# Altium schematic sheet CL212I31-POW.SchDoc
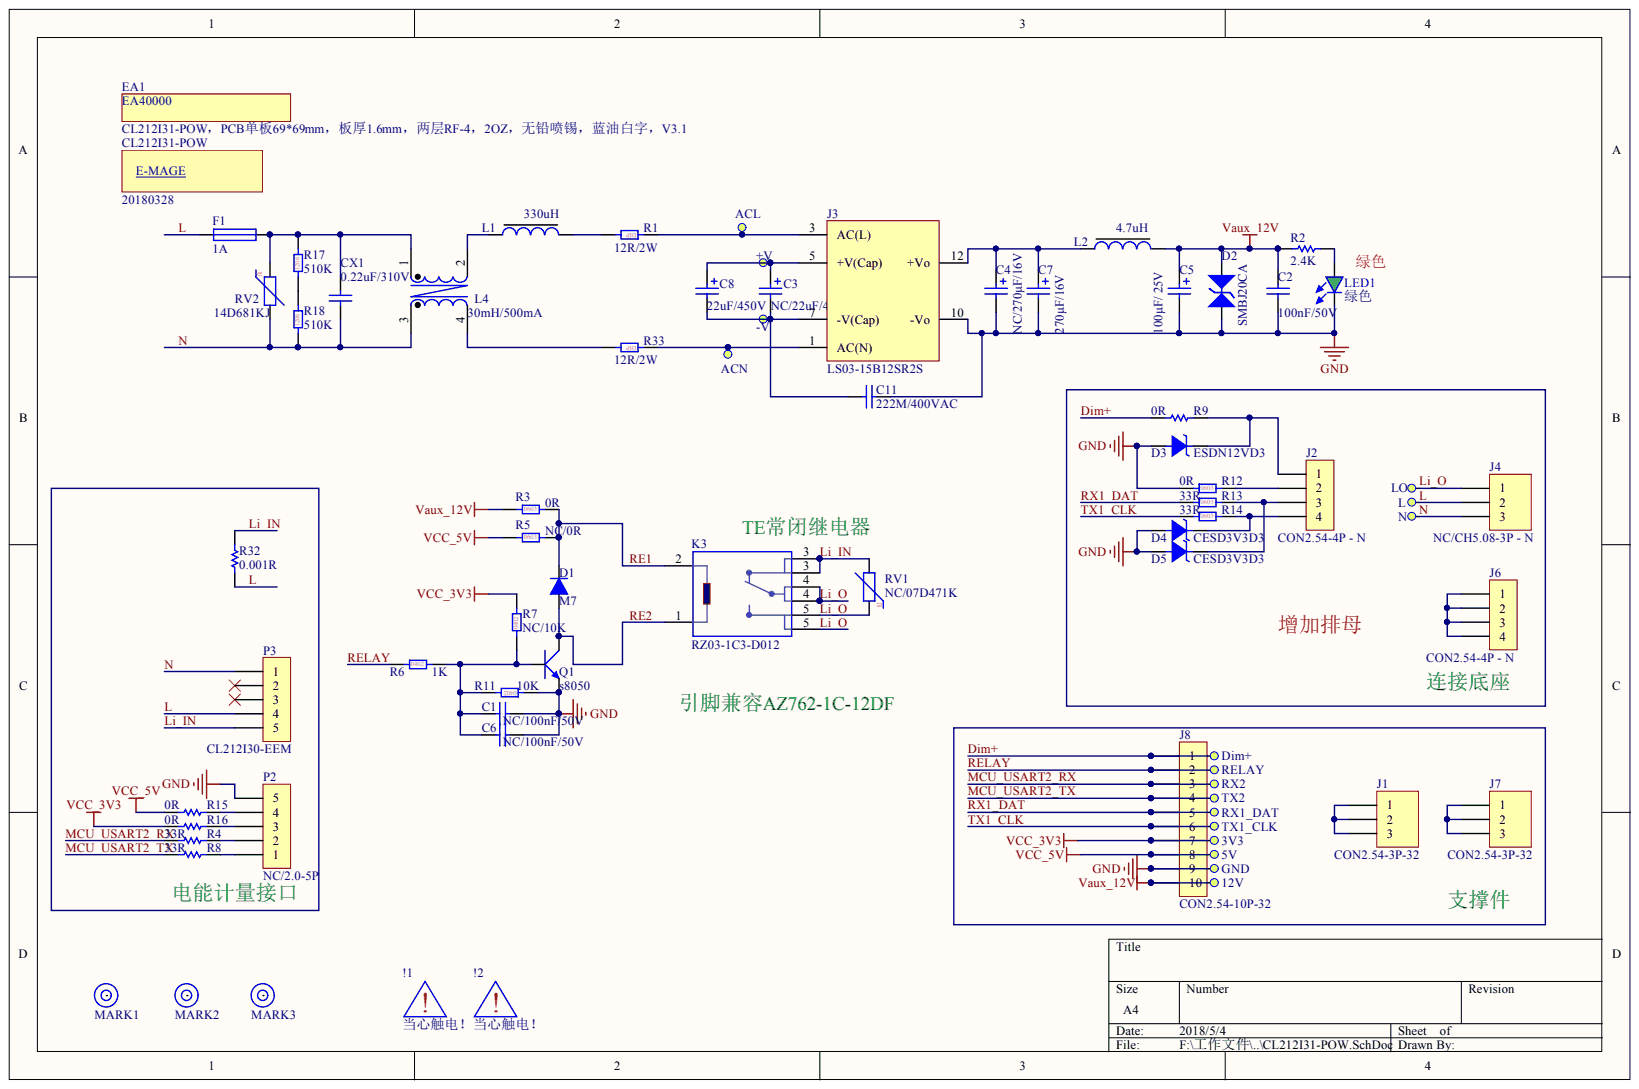
[diagram p0: CL212I31-POW.SchDoc]

## Parts
3V3  Test-Point  [Test-Point]  (value not drawn)  (no BOM)
5V  Test-Point  [Test-Point]  (value not drawn)  (no BOM)
12V  Test-Point  [Test-Point]  (value not drawn)  (no BOM)
!1  NC  [BMP1]  (value not drawn)
!2  NC  [BMP1]  (value not drawn)
+V  Test-Point  [Test-Point]  (value not drawn)  (no BOM)
-V  Test-Point  [Test-Point]  (value not drawn)  (no BOM)
ACL  Test-Point  [Test-Point]  (value not drawn)  (no BOM)
ACN  Test-Point  [Test-Point]  (value not drawn)  (no BOM)
C1  NC/100nF/50V  [C0402]
C2  100nF/50V  [C0402]
C3  NC/22uF/450V  [CE16*25_7.5]
C4  NC/270µF/16V  [CE8*9_3.5]
C5  100µF/ 25V  [CE6.3*7.7-SM]
C6  NC/100nF/50V  [C0402]
C7  270µF/16V  [CE6.3*9_2.5]
C8  22uF/450V  [CE12.5*20_5]
C11  222M/400VAC  [C10MM]
CL212I31-POW  20180328  [E-MAGE]
CX1  0.22uF/310V  [RAD-10MM]
D1  M7  [sma]
D2  SMBJ20CA  [smb]
D3  ESDN12VD3  [SOD323]
D4  CESD3V3D3  [SOD323]
D5  CESD3V3D3  [SOD323]
Dim+  Test-Point  [Test-Point]  (value not drawn)  (no BOM)
EA1  CL212I31-POW，PCB单板69*69mm，板厚1.6mm，两层RF-4，2OZ，无铅喷锡，蓝油白字，V3.1  [PCB_Coding]
F1  1A  [F_R8.4_4]
GND  Test-Point  [Test-Point]  (value not drawn)  (no BOM)
J1  CON2.54-3P-32  [CON2.54-3P-32]
J2  CON2.54-4P - N  [CON2.54-4P - N]
J3  LS03-15B12SR2S  [LS03-15B12SR2S]
J4  NC/CH5.08-3P - N  [CH5.08-3P - N]
J6  CON2.54-4P - N  [CON2.54-4P - N]
J7  CON2.54-3P-32  [CON2.54-3P-32]
J8  CON2.54-10P-32  [CON2.54-10P-32]
K3  RZ03-1C3-D012  [RELAY_TE_RZ]
L  Test-Point  [Test-Point]  (value not drawn)  (no BOM)
L1  330uH  [L_8*10-5]  (net tie)
L2  4.7uH  [L-HNR4030]  (net tie)
L4  30mH/500mA  [UU98]
LED1  绿色  [LED0603 G]
LO  Test-Point  [Test-Point]  (value not drawn)  (no BOM)
MARK1  MARK  [MARK]
MARK2  MARK  [MARK]
MARK3  MARK  [MARK]
N  Test-Point  [Test-Point]  (value not drawn)  (no BOM)
P2  NC/2.0-5P  [CON2.0-5P]
P3  CL212I30-EEM  [CON2.0-5P-8209C]
Q1  s8050  [sot23-3]
R1  12R/2W  [R_AXIAL_2W_5.08_90]
R2  2.4K  [R0805]
R3  0R  [R0603]
R4  33R  [R0402]
R5  NC/0R  [R0603]
R6  1K  [R0402]
R7  NC/10K  [R0402]
R8  33R  [R0402]
R9  0R  [R0402]
R11  10K  [R0402]
R12  0R  [R0603]
R13  33R  [R0603]
R14  33R  [R0603]
R15  0R  [R0402]
R16  0R  value 33R  [R0402]
R17  510K  [R1206]
R18  510K  [R1206]
R32  0.001R  [R2512 - MT]
R33  12R/2W  [R_AXIAL_2W_5.08_90]
RELAY  Test-Point  [Test-Point]  (value not drawn)  (no BOM)
RV1  NC/07D471K  [RV_07D471K]
RV2  14D681KJ  [RV_14D]
RX1_DAT  Test-Point  [Test-Point]  (value not drawn)  (no BOM)
RX2  Test-Point  [Test-Point]  (value not drawn)  (no BOM)
TX1_CLK  Test-Point  [Test-Point]  (value not drawn)  (no BOM)
TX2  Test-Point  [Test-Point]  (value not drawn)  (no BOM)

## Nets
GND: C1.2 C2.2 C4.2 C5.2 C6.2 C7.2 C11.2 D2.1 D3.1 D4.1 D5.1 GND.0 J3.10(-Vo) J8.9 LED1.2 P2.5 Q1.2 R11.1 R12.1
NetMARK1: MARK1.
NetMARK2: MARK2.
NetMARK3: MARK3.
VAUX_12V: 12V.0 C2.1 C5.1 D2.2 J8.10 L2.2 R2.2 R3.1
VCC_3V3: 3V3.0 J8.7 R7.2 R16.1
VCC_5V: 5V.0 J8.8 R5.1 R15.1
DIM+: Dim+.0 J8.1 R9.1
L: F1.2 J4.2 L.0 P3.4 R32.2
LI_IN: K3.3 P3.5 R32.1 RV1.2
LI_O: J4.1 K3.4 K3.5 LO.0 RV1.1
MCU_USART2_RX: J8.3 R4.1 RX2.0
MCU_USART2_TX: J8.4 R8.1 TX2.0
N: CX1.2 J4.3 L4.3 N.0 P3.1 R18.1 RV2.2
RE1: D1.2 K3.2 R3.2 R5.2
RE2: D1.1 K3.1 Q1.3
RELAY: J8.2 R6.1 RELAY.0
RX1_DAT: J8.5 R13.1 RX1_DAT.0
TX1_CLK: J8.6 R14.1 TX1_CLK.0
Net+V_0: +V.0 C3.1 C8.1 J3.5(+V(Cap))
Net-V_0: -V.0 C3.2 C8.2 C11.1 J3.7(-V(Cap))
NetACL_0: ACL.0 J3.3(AC(L)) R1.1
NetACN_0: ACN.0 J3.1(AC(N)) R33.1
NetC1_1: C1.1 C6.1 Q1.1 R6.2 R7.1 R11.2
NetC4_1: C4.1 C7.1 J3.12(+Vo) L2.1
NetCX1_1: CX1.1 F1.1 L4.1 R17.2 RV2.1
NetD3_2: D3.2 J2.1 R9.2
NetD4_2: D4.2 J2.4 R14.2
NetD5_2: D5.2 J2.3 R13.2
NetJ1_1: J1.1 J1.2 J1.3
NetJ2_2: J2.2 R12.2
NetJ6_1: J6.1 J6.2 J6.3 J6.4
NetJ7_1: J7.1 J7.2 J7.3
NetL1_1: L1.1 L4.2
NetL1_2: L1.2 R1.2
NetL4_4: L4.4 R33.2
NetLED1_1: LED1.1 R2.1
NetP2_1: P2.1 R8.2
NetP2_2: P2.2 R4.2
NetP2_3: P2.3 R16.2
NetP2_4: P2.4 R15.2
NetR17_1: R17.1 R18.2

Not connected: P3 2 3

## Library data of the parts
!1 !2 = BMP1: : 当心触电！; Component Kind: Standard; Library Name: Emaga SCH.SchLib
C1 C2 C6 = Cap: Cap SMD_C0402_100nF_5%_10V_U2J; Value: 100nF; Component Kind: Standard; LatestRevisionDate: 05-oct-2007  -  Semtech; LatestRevisionNote: Re-released for DXP Platform.; Published: 8-Jun-2000; Signal Integrity: Cap Semi; Simulation: CAP; Library Name: Emaga SCH.SchLib; Publisher: Altium Limited
C3 = Cap Pol1: 智宝SP电解电容，16*25，105℃，8000h; Value: 220uF; Component Kind: Standard; LatestRevisionDate: 17-Jul-2002; LatestRevisionNote: Re-released for DXP Platform.; PackageDescription: Polarized Capacitor (Radial); 2 Leads; PackageReference: RB7.6-15; Published: 8-Jun-2000; Signal Integrity: Cap Pol; Simulation: CAP; Library Name: Emaga SCH.SchLib; Publisher: Altium Limited
C4 = Cap Pol1: 智宝CH固态电解电容， 8*8 ，ESR=10 ，105℃，5000h; Value: 220uF; Component Kind: Standard; LatestRevisionDate: 17-Jul-2002; LatestRevisionNote: Re-released for DXP Platform.; PackageDescription: Polarized Capacitor (Radial); 2 Leads; PackageReference: RB7.6-15; Published: 8-Jun-2000; Signal Integrity: Cap Pol; Simulation: CAP; Library Name: Emaga SCH.SchLib; Publisher: Altium Limited
C5 = Cap Pol1: EV高频低阻贴片电解电容， 6.3*7.7，105℃，2000h; Value: 220uF; Component Kind: Standard; LatestRevisionDate: 17-Jul-2002; LatestRevisionNote: Re-released for DXP Platform.; PackageDescription: Polarized Capacitor (Radial); 2 Leads; PackageReference: RB7.6-15; Published: 8-Jun-2000; Signal Integrity: Cap Pol; Simulation: CAP; Library Name: Emaga SCH.SchLib; Publisher: Altium Limited
C7 = Cap Pol1: FG固态电解电容， 6.3*9 ，ESR=20 ，105℃，2000h; Value: 220uF; Component Kind: Standard; LatestRevisionDate: 17-Jul-2002; LatestRevisionNote: Re-released for DXP Platform.; PackageDescription: Polarized Capacitor (Radial); 2 Leads; PackageReference: RB7.6-15; Published: 8-Jun-2000; Signal Integrity: Cap Pol; Simulation: CAP; Library Name: Emaga SCH.SchLib; Publisher: Altium Limited
C8 = Cap Pol1: SU插件铝电解电容，12.5*20，105℃，12000h; Value: 220uF; Component Kind: Standard; LatestRevisionDate: 17-Jul-2002; LatestRevisionNote: Re-released for DXP Platform.; PackageDescription: Polarized Capacitor (Radial); 2 Leads; PackageReference: RB7.6-15; Published: 8-Jun-2000; Signal Integrity: Cap Pol; Simulation: CAP; Library Name: Emaga SCH.SchLib; Publisher: Altium Limited
C11 = Cap: Y电容; Value: 100nF; Component Kind: Standard; LatestRevisionDate: 05-oct-2007  -  Semtech; LatestRevisionNote: Re-released for DXP Platform.; Published: 8-Jun-2000; Signal Integrity: Cap Semi; Simulation: CAP; Library Name: Emaga SCH.SchLib; Publisher: Altium Limited
CL212I31-POW = E-MAGE: Component Kind: Standard; Library Name: Emaga SCH.SchLib
CX1 = Cap: X安规电容 0.22uF（224）C3 13*12*8 P=10mm; Value: 100nF; Component Kind: Standard; LatestRevisionDate: 05-oct-2007  -  Semtech; LatestRevisionNote: Re-released for DXP Platform.; Published: 8-Jun-2000; Signal Integrity: Cap Semi; Simulation: CAP; Library Name: Emaga SCH.SchLib; Publisher: Altium Limited
D1 = (no symbol name): 1N4007 整流 Vr=1000V If=1A Vf=1.1V; Manufacturer: TOSHIBA; bAccessary: False; bCGFirst: 0; bComsume: True; bSynchroBom: 是; cComUnitCode: 0101; cCreatePerson: 贵永龙; cDefWareHouse: 001; cGroupCode: 01; cInvCode: 010030005; cInvStd: M4; cModifyPerson: 古兰; cValueType: 移动平均法; fInExcess: 1; fOutExcess: 0.3; I_id: 70; iDTMethod: -1; iInvAdvance: 5; bBarCode: False; bFixExch: False; bFree1: False; bFree2: False; bFree3: False; bFree4: False; bFree5: False; bFree6: False; bFree7: False; bFree8: False; bFree9: False; bFree10: False; bInvBatch: False; bInvEntrust: False; bInvOverStock: False; bInvQuality: False; bInvType: False; bKCCutMantissa: False; bProducing: False; bPromotSales: False; bPropertyCheck: False; bProxyForeign: False; bPurchase: True; bRequireGroup: False; bSale: False; bSelf: False; bSerial: False; bService: False; bSolitude: False; bSpecialties: False; bTrack: False; cInvCCode: 01; Component Kind: Standard; cVenCode: 001; dLastDate: 2015-05-19; dModifyDate: 2015-06-11; dSDate: 2015-04-15; FootprintType: 贴片; iAdvanceDate: 5; iBatchRule: 1; iDTStyle: -1; iGroupType: 0; iPlanPolicy: 1; iRecipeBatch: 0; iTaxRate: 0; iTestStyle: -1; Manufacturer Part Number: M4; Part Type: 二极管; Library Name: Emaga SCH.SchLib
D2 = 010030013: TVS瞬态抑制二极管 双向; Database Table Name: Inventory; 单价: ￥; 封装类型: 贴片; 类型: TVS二极管; 规格/型号: SMBJ6.0CA，6V 600W 10.33A SMB(DO; Component Kind: Standard; Library Name: Altium_Database_Libs.DbLib
D3-D5 = (no symbol name): TVS瞬态抑制二极管 单向; bAccessary: False; bCGFirst: 0; bComsume: True; bSynchroBom: 是; cComUnitCode: 0101; cCreatePerson: 贵永龙; cDefWareHouse: 001; cGroupCode: 01; cInvCode: 010080003; cInvStd: ESD/5V; cValueType: 移动平均法; cVenCode: 01017; fInExcess: 1; I_id: 197; iInvAdvance: 5; bBarCode: False; bFixExch: False; bFree1: False; bFree2: False; bFree3: False; bFree4: False; bFree5: False; bFree6: False; bFree7: False; bFree8: False; bFree9: False; bFree10: False; bInvBatch: False; bInvEntrust: False; bInvOverStock: False; bInvQuality: False; bInvType: False; bKCCutMantissa: False; bProducing: False; bPromotSales: False; bPropertyCheck: False; bProxyForeign: False; bPurchase: True; bRequireGroup: False; bSale: False; bSelf: False; bSerial: False; bService: False; bSolitude: False; bSpecialties: False; bTrack: False; cInvCCode: 01; Component Kind: Standard; dLastDate: 2015-05-19; dModifyDate: 2015-07-21; dSDate: 2015-04-15; FootprintType: 贴片; iBatchRule: 1; iGroupType: 0; iPlanPolicy: 1; iRecipeBatch: 0; iTaxRate: 0; Part Type: ESD; cModifyPerson: 贵永龙; Library Name: Emaga SCH.SchLib
EA1 = PCB_Coding: CL212I31-POW板PCB; 规格/型号: PCB单板188*121.5mm，板厚1.6mm，双面RF-4国标A1，1OZ，无铅喷锡，绿油白字; Component Kind: Standard; Library Name: RK-KYJ-300.SCHLIB
F1 = Fuse: 8.4*4方形保险，慢断，1A 300V; Value: Fuse; Component Kind: Standard; LatestRevisionDate: 17-Jul-2002; LatestRevisionNote: Re-released for DXP Platform.; PackageDescription: Thru-Hole; 2 Leads; PackageReference: PIN-W2/E2.8; Published: 8-Jun-2000; Simulation: FUSE; Library Name: Emaga SCH.SchLib; Publisher: Altium Limited
J1 J7 = Header 3: 2.54mm直插单排双塑插针，针长32mm，双塑一边针露出3mm，一边针露出7mm，镀金; Component Kind: Standard; LatestRevisionDate: 17-Jul-2002; LatestRevisionNote: Re-released for DXP Platform.; Library Name: Miscellaneous Connectors.IntLib; Publisher: Altium Limited
J2 J6 = CON2.0-4P: 2.54mm直插单排母，塑高8.5mm，镀金（焊在反面）; Component Kind: Standard; LatestRevisionDate: 17-Jul-2002; LatestRevisionNote: Re-released for DXP Platform.; Signal Integrity: Connector; Library Name: Emaga SCH.SchLib; Publisher: Altium Limited
J3 = LS03-15B12SR2S: 金升阳AC-DC开关电源模块，85~305V转+12V，250mA，-40~85℃; Component Kind: Standard; Library Name: Emaga SCH.SchLib
J4 = Header 3: Component Kind: Standard; LatestRevisionDate: 17-Jul-2002; LatestRevisionNote: Re-released for DXP Platform.; Library Name: Miscellaneous Connectors.IntLib; Publisher: Altium Limited
J8 = CON2.0-10P: 2.54mm直插单排双塑插针，针长32mm，双塑一边针露出3mm，一边针露出7mm，镀金; Component Kind: Standard; LatestRevisionDate: 17-Jul-2002; LatestRevisionNote: Re-released for DXP Platform.; Signal Integrity: Connector; Library Name: Emaga SCH.SchLib; Publisher: Altium Limited
K3 = (no symbol name): TE 泰科继电器_转换型_16A_DC12V_浪涌电流80A; Manufacturer: 宏发; bAccessary: False; bCGFirst: 0; bComsume: True; bSynchroBom: 是; cComUnitCode: 0101; cDefWareHouse: 001; cGroupCode: 01; cInvCode: 010110002; cInvStd: HF46F/5-HS1; cValueType: 移动平均法; cVenCode: 01006; fInExcess: 1; I_id: 175; iDTMethod: -1; iInvAdvance: 5; bBarCode: False; bFixExch: False; bFree1: False; bFree2: False; bFree3: False; bFree4: False; bFree5: False; bFree6: False; bFree7: False; bFree8: False; bFree9: False; bFree10: False; bInvBatch: False; bInvEntrust: False; bInvOverStock: False; bInvQuality: False; bInvType: False; bKCCutMantissa: False; bProducing: False; bPromotSales: False; bPropertyCheck: False; bProxyForeign: False; bPurchase: True; bRequireGroup: False; bSale: False; bSelf: False; bSerial: False; bService: False; bSolitude: False; bSpecialties: False; bTrack: False; cInvCCode: 01; Component Kind: Standard; dLastDate: 2015-05-19; dModifyDate: 2015-06-12; dSDate: 2015-04-15; FootprintType: 直插; iBatchRule: 1; iDTStyle: -1; iGroupType: 0; iPlanPolicy: 1; iRecipeBatch: 0; iTaxRate: 0; iTestStyle: -1; Manufacturer Part Number: HF46F/5-HS1; Part Type: 继电器; Library Name: Emaga SCH.SchLib
L1 = L-Inductor: CP0808AI-331KH，插件工字电感 ，Ø7.5*8; Code_IEC: 1005; Code_JEITA: 0402; LatestRevisionDate: 05-oct-2007  -  Semtech; LatestRevisionNote: Re-released for DXP Platform.; Published: 8-Jun-2000; Signal Integrity: Inductor; Simulation: INDUCTOR; Library Name: Emaga SCH.SchLib; Publisher: Altium Limited
L2 = L-Inductor: HNR4030-4R7M-N，功率电感，向华电子（奇力新），4*4*3mm，4.7uH，±20%，2.1A; Code_IEC: 1005; Code_JEITA: 0402; LatestRevisionDate: 05-oct-2007  -  Semtech; LatestRevisionNote: Re-released for DXP Platform.; Published: 8-Jun-2000; Signal Integrity: Inductor; Simulation: INDUCTOR; Library Name: Emaga SCH.SchLib; Publisher: Altium Limited
L4 = (no symbol name): 直插立式共模电感滤波器，500mA，UU9.8，30mH; bAccessary: False; bCGFirst: 0; bComsume: True; bSynchroBom: 是; cComUnitCode: 0101; cCreatePerson: 贵永龙; cDefWareHouse: 001; cGroupCode: 01; cInvCode: 010050011; cInvStd: 10mH/0.5A; cValueType: 移动平均法; cVenCode: 01017; fInExcess: 1; fOutExcess: 0.3; I_id: 236; iInvAdvance: 5; bBarCode: False; bFixExch: False; bFree1: False; bFree2: False; bFree3: False; bFree4: False; bFree5: False; bFree6: False; bFree7: False; bFree8: False; bFree9: False; bFree10: False; bInvBatch: False; bInvEntrust: False; bInvOverStock: False; bInvQuality: False; bInvType: False; bKCCutMantissa: False; bProducing: False; bPromotSales: False; bPropertyCheck: False; bProxyForeign: False; bPurchase: True; bRequireGroup: False; bSale: False; bSelf: False; bSerial: False; bService: False; bSolitude: False; bSpecialties: False; bTrack: False; cInvCCode: 01; Component Kind: Standard; dLastDate: 2015-05-19; dModifyDate: 2015-12-04; dSDate: 2015-04-15; FootprintType: 直插; iBatchRule: 1; iGroupType: 0; iPlanPolicy: 1; iRecipeBatch: 0; iTaxRate: 0; Part Type: 共模电感; cModifyPerson: 贵永龙; Library Name: Emaga SCH.SchLib
LED1 = (no symbol name): 绿色贴片LED; bAccessary: False; bCGFirst: 0; bComsume: True; bSynchroBom: 是; cComUnitCode: 0101; cCreatePerson: 贵永龙; cDefWareHouse: 001; cGroupCode: 01; cInvCode: 010060006; cInvStd: 红色-sd0603; cModifyPerson: 古兰; cValueType: 移动平均法; cVenCode: 01017; fInExcess: 1; fOutExcess: 0.3; I_id: 172; iDTMethod: -1; iInvAdvance: 5; bBarCode: False; bFixExch: False; bFree1: False; bFree2: False; bFree3: False; bFree4: False; bFree5: False; bFree6: False; bFree7: False; bFree8: False; bFree9: False; bFree10: False; bInvBatch: False; bInvEntrust: False; bInvOverStock: False; bInvQuality: False; bInvType: False; bKCCutMantissa: False; bProducing: False; bPromotSales: False; bPropertyCheck: False; bProxyForeign: False; bPurchase: True; bRequireGroup: False; bSale: False; bSelf: False; bSerial: False; bService: False; bSolitude: False; bSpecialties: False; bTrack: False; cInvCCode: 01; Component Kind: Standard; dLastDate: 2015-05-19; dModifyDate: 2015-07-13; dSDate: 2015-04-15; FootprintType: 贴片; iAdvanceDate: 5; iBatchRule: 1; iDTStyle: -1; iGroupType: 0; iPlanPolicy: 1; iRecipeBatch: 0; iTaxRate: 0; iTestStyle: -1; Part Type: LED; Library Name: Emaga SCH.SchLib
P2 = CON2.0-5P: Component Kind: Standard; LatestRevisionDate: 17-Jul-2002; LatestRevisionNote: Re-released for DXP Platform.; Signal Integrity: Connector; Library Name: Emaga SCH.SchLib; Publisher: Altium Limited
P3 = CON2.0-5P: CL212I30-EEM板PCBA，焊接位号：P2、P3; Component Kind: Standard; LatestRevisionDate: 17-Jul-2002; LatestRevisionNote: Re-released for DXP Platform.; Signal Integrity: Connector; Library Name: Emaga SCH.SchLib; Publisher: Altium Limited
Q1 = (no symbol name): 贴片三极管  J3Y; Manufacturer: Changjiang; bAccessary: False; bCGFirst: 0; bComsume: True; bSynchroBom: 是; cComUnitCode: 0101; cCreatePerson: 贵永龙; cDefWareHouse: 001; cGroupCode: 01; cInvCode: 010040001; cInvStd: S8050 J3Y; cModifyPerson: 古兰; cValueType: 移动平均法; cVenCode: 01017; fInExcess: 1; fOutExcess: 0.3; I_id: 76; iDTMethod: -1; iInvAdvance: 5; bBarCode: False; bFixExch: False; bFree1: False; bFree2: False; bFree3: False; bFree4: False; bFree5: False; bFree6: False; bFree7: False; bFree8: False; bFree9: False; bFree10: False; bInvBatch: False; bInvEntrust: False; bInvOverStock: False; bInvQuality: False; bInvType: False; bKCCutMantissa: False; bProducing: False; bPromotSales: False; bPropertyCheck: False; bProxyForeign: False; bPurchase: True; bRequireGroup: False; bSale: False; bSelf: False; bSerial: False; bService: False; bSolitude: False; bSpecialties: False; bTrack: False; cInvCCode: 01; Component Kind: Standard; dLastDate: 2015-05-20; dModifyDate: 2015-07-13; dSDate: 2015-04-15; FootprintType: 贴片; iAdvanceDate: 5; iBatchRule: 1; iDTStyle: -1; iGroupType: 0; iPlanPolicy: 1; iRecipeBatch: 0; iTaxRate: 0; iTestStyle: -1; Manufacturer Part Number: S8050 J3Y; Part Type: 三极管; Library Name: Emaga SCH.SchLib
R1 R33 = Res_DIP: 金属氧化膜插件电阻_12R_2W; Component Kind: Standard; Published: 8-Jun-2000; Revision: July-2002: Re-released for DXP Platform.; Signal Integrity: Res; Simulation: RESISTOR; Library Name: Emaga SCH.SchLib; Publisher: Altium Limited
R2 = Res_SMD: Res SMD_R0402_0R_5%_1/16W; Component Kind: Standard; Published: 8-Jun-2000; Revision: July-2002: Re-released for DXP Platform.; Signal Integrity: Res; Simulation: RESISTOR; Library Name: Emaga SCH.SchLib; Publisher: Altium Limited
R3 R5 R12-R14 = Res_0603: Res SMD_R0402_0R_5%_1/16W; Component Kind: Standard; Published: 8-Jun-2000; Revision: July-2002: Re-released for DXP Platform.; Signal Integrity: Res; Simulation: RESISTOR; Library Name: Emaga SCH.SchLib; Publisher: Altium Limited
R4 R8 R16 = Res1: Res SMD_R0402_33R_5%_1/16W; Component Kind: Standard; Library Name: Miscellaneous Devices.IntLib; Published: 8-Jun-2000; Revision: July-2002: Re-released for DXP Platform.; Signal Integrity: Res; Simulation: RESISTOR; Publisher: Altium Limited
  R4 R8: Value: 33R
R6 R7 R11 = Res_0402: Res SMD_R0402_0R_5%_1/16W; Component Kind: Standard; Published: 8-Jun-2000; Revision: July-2002: Re-released for DXP Platform.; Signal Integrity: Res; Simulation: RESISTOR; Library Name: Emaga SCH.SchLib; Publisher: Altium Limited
R9 R15 = Res1: Res SMD_R0402_33R_5%_1/16W; Value: 33R; Component Kind: Standard; Library Name: Miscellaneous Devices.IntLib; Published: 8-Jun-2000; Revision: July-2002: Re-released for DXP Platform.; Signal Integrity: Res; Simulation: RESISTOR; Publisher: Altium Limited
R17 R18 = Res_1206: 贴片电阻; Component Kind: Standard; Published: 8-Jun-2000; Revision: July-2002: Re-released for DXP Platform.; Signal Integrity: Res; Simulation: RESISTOR; Library Name: Emaga SCH.SchLib; Publisher: Altium Limited
R32 = Res_SMD: 锰铜电阻，1mR; Component Kind: Standard; Published: 8-Jun-2000; Revision: July-2002: Re-released for DXP Platform.; Signal Integrity: Res; Simulation: RESISTOR; Library Name: Emaga SCH.SchLib; Publisher: Altium Limited
RV1 = MOV: 压敏电阻; Component Kind: Standard; Library Name: Emaga SCH.SchLib
RV2 = MOV: HEL压敏电阻_14D681KJ_±10%_压敏电压680V_最大使用电压420VAC_限制电压最大值1120V_一次脉冲最大电流6KA_电流冲击3KA（15次）_-40~85℃; Component Kind: Standard; Library Name: Emaga SCH.SchLib
3V3 5V 12V +V -V ACL ACN Dim+ GND L LO N RELAY RX1_DAT RX2 TX1_CLK TX2 = Test-Point: Library Name: SCH lib.SchLib
MARK1-MARK3 = MARK: Component Kind: Standard; Description: MARK; Library Name: SJ-S-105LMH.pdf.SchLib
